# Altium schematic sheet main.SchDoc
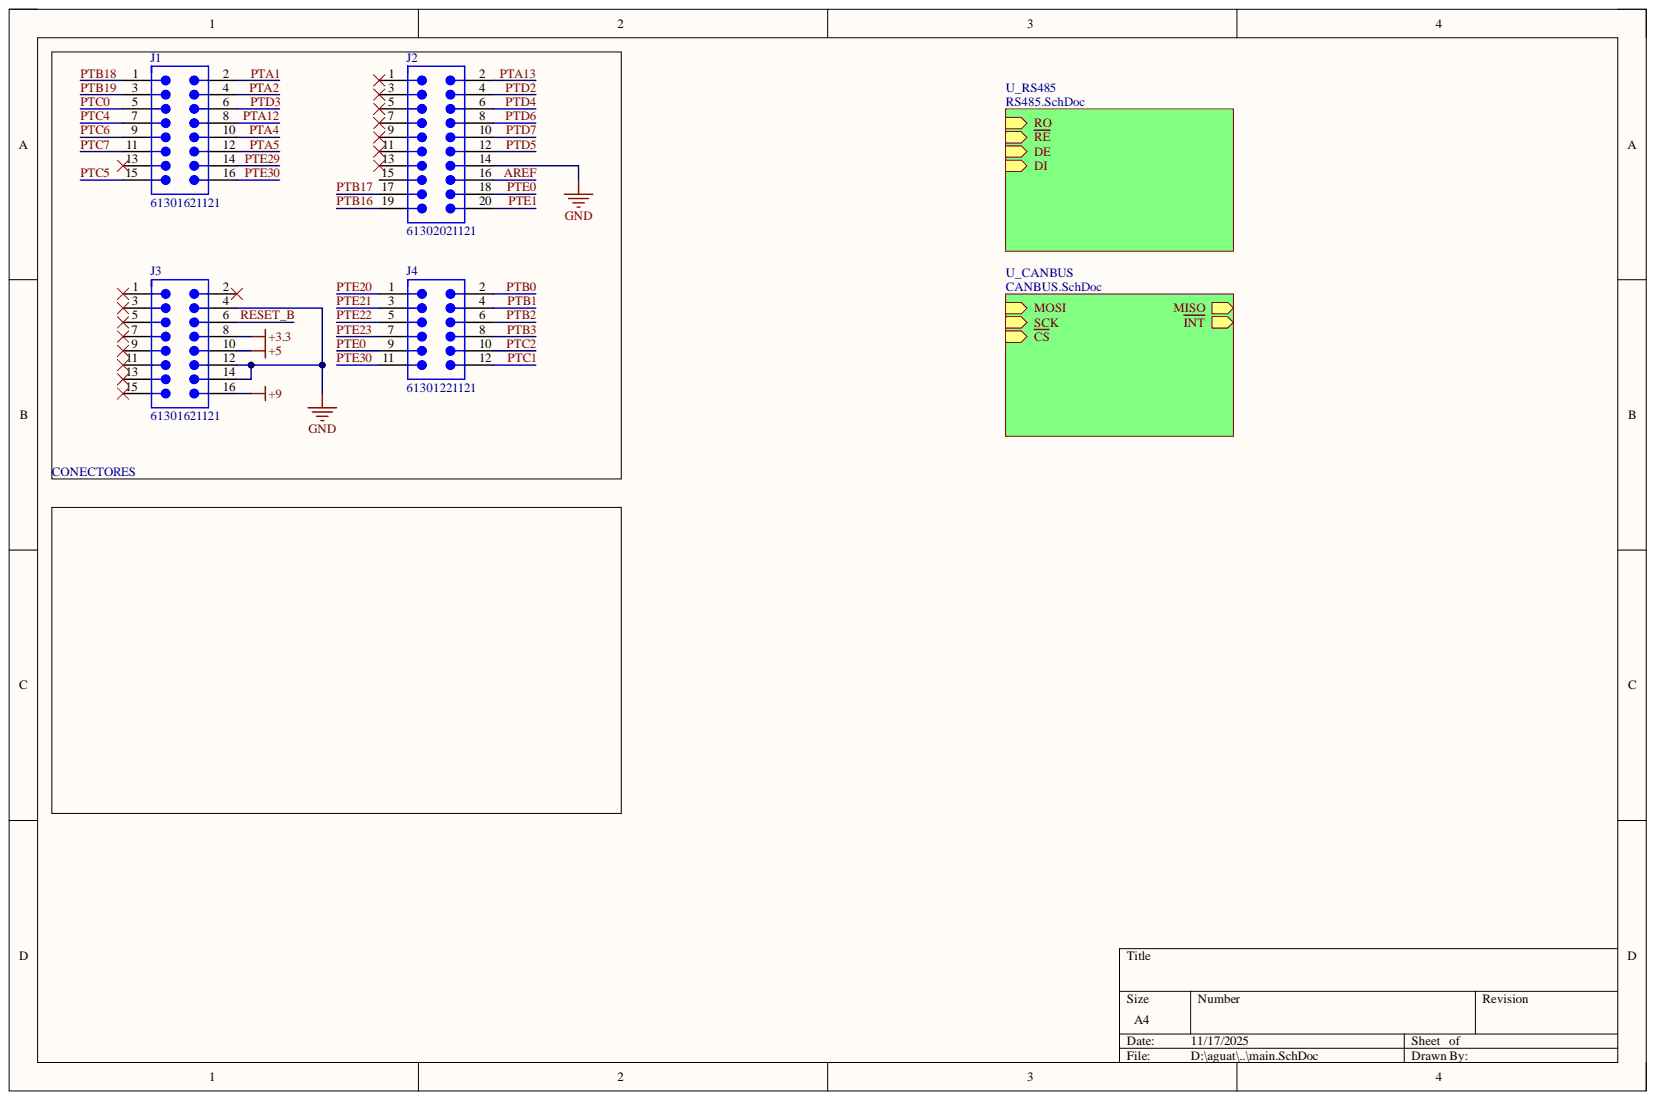
[diagram p0: main.SchDoc]

## Parts
J1  61301621121  [61301621121]
J2  61302021121  [61302021121]
J3  61301621121  [61301621121]
J4  61301221121  [61301221121]

## Nets
+3.3: J3.8
+5: J3.10
+9: J3.16
GND: J2.14 J3.4 J3.12 J3.14
AREF: J2.16
PTA1: J1.2
PTA2: J1.4
PTA4: J1.10
PTA5: J1.12
PTA12: J1.8
PTA13: J2.2
PTB0: J4.2
PTB1: J4.4
PTB2: J4.6
PTB3: J4.8
PTB16: J2.19
PTB17: J2.17
PTB18: J1.1
PTB19: J1.3
PTC0: J1.5
PTC1: J4.12
PTC2: J4.10
PTC4: J1.7
PTC5: J1.15
PTC6: J1.9
PTC7: J1.11
PTD2: J2.4
PTD3: J1.6
PTD4: J2.6
PTD5: J2.12
PTD6: J2.8
PTD7: J2.10
PTE0: J2.18 J4.9
PTE1: J2.20
PTE20: J4.1
PTE21: J4.3
PTE22: J4.5
PTE23: J4.7
PTE29: J1.14
PTE30: J1.16 J4.11
RESET_B: J3.6
NetJ1_13: J1.13
NetJ2_1: J2.1
NetJ2_3: J2.3
NetJ2_5: J2.5
NetJ2_7: J2.7
NetJ2_9: J2.9
NetJ2_11: J2.11
NetJ2_13: J2.13
NetJ2_15: J2.15
NetJ3_1: J3.1
NetJ3_2: J3.2
NetJ3_3: J3.3
NetJ3_5: J3.5
NetJ3_7: J3.7
NetJ3_9: J3.9
NetJ3_11: J3.11
NetJ3_13: J3.13
NetJ3_15: J3.15
~{INT}:
~{CS}:
DE:
DI:
MISO:
MOSI:
~{RE}:
RO:
SCK:

## Library data of the parts
J1 J3 = CMP-1502-01097-3: NexarPartId: 32855550; Connector Type: Male Pin; Contact Resistance: 20mΩ; Current Rating: 3A; Gender: Male; Number of Contacts: 16; Operating Supply Voltage: 250V; Pitch: 2.54mm; Color: Black; Component Kind: Standard; Contact Material: Copper; Contact Mating Length: 5.9944mm; Contact Plating: Gold; Height: 8.89mm; Lead Pitch: 2.54mm; Library Name: Altium Content Vault; Max Operating Temperature: 105°C; Min Operating Temperature: -40°C; Mount: Through Hole; Number of Pins: 16; Number of Positions: 16; Number of Rows: 2; Orientation: Vertical; Packaging: Bulk; REACH SVHC: Unknown; RoHS: Compliant; Row Spacing: 2.54mm; Termination: Solder; Voltage Rating: 250V
J2 = CMP-1502-01104-3: NexarPartId: 32855556; Connector Type: Male Pin; Contact Resistance: 20mΩ; Current Rating: 3A; Gender: Male; Number of Contacts: 20; Operating Supply Voltage: 250V; Pitch: 2.54mm; Color: Black; Component Kind: Standard; Contact Material: Copper; Contact Mating Length: 5.9944mm; Contact Plating: Gold; Lead Pitch: 2.54mm; Library Name: Altium Content Vault; Mount: Through Hole; Number of Pins: 20; Number of Positions: 20; Number of Rows: 2; Orientation: Vertical; Packaging: Bulk; REACH SVHC: Unknown; RoHS: Compliant; Row Spacing: 2.54mm; Termination: Solder
J4 = CMP-1502-01088-4: NexarPartId: 32855540; Connector Type: Male Pin; Contact Resistance: 20mΩ; Current Rating: 3A; Gender: Male; Number of Contacts: 12; Operating Supply Voltage: 250V; Pitch: 2.54mm; Color: Black; Component Kind: Standard; Contact Material: Copper; Contact Mating Length: 5.9944mm; Contact Plating: Gold; Height: 8.89mm; Lead Pitch: 2.54mm; Library Name: Altium Content Vault; Max Operating Temperature: 105°C; Min Operating Temperature: -40°C; Mount: Through Hole; Number of Pins: 12; Number of Positions: 12; Number of Rows: 2; Orientation: Vertical; Packaging: Bulk; REACH SVHC: Unknown; RoHS: Compliant; Row Spacing: 2.54mm; Termination: Solder; Voltage Rating: 250V
